# Altium schematic sheet MCU.SchDoc
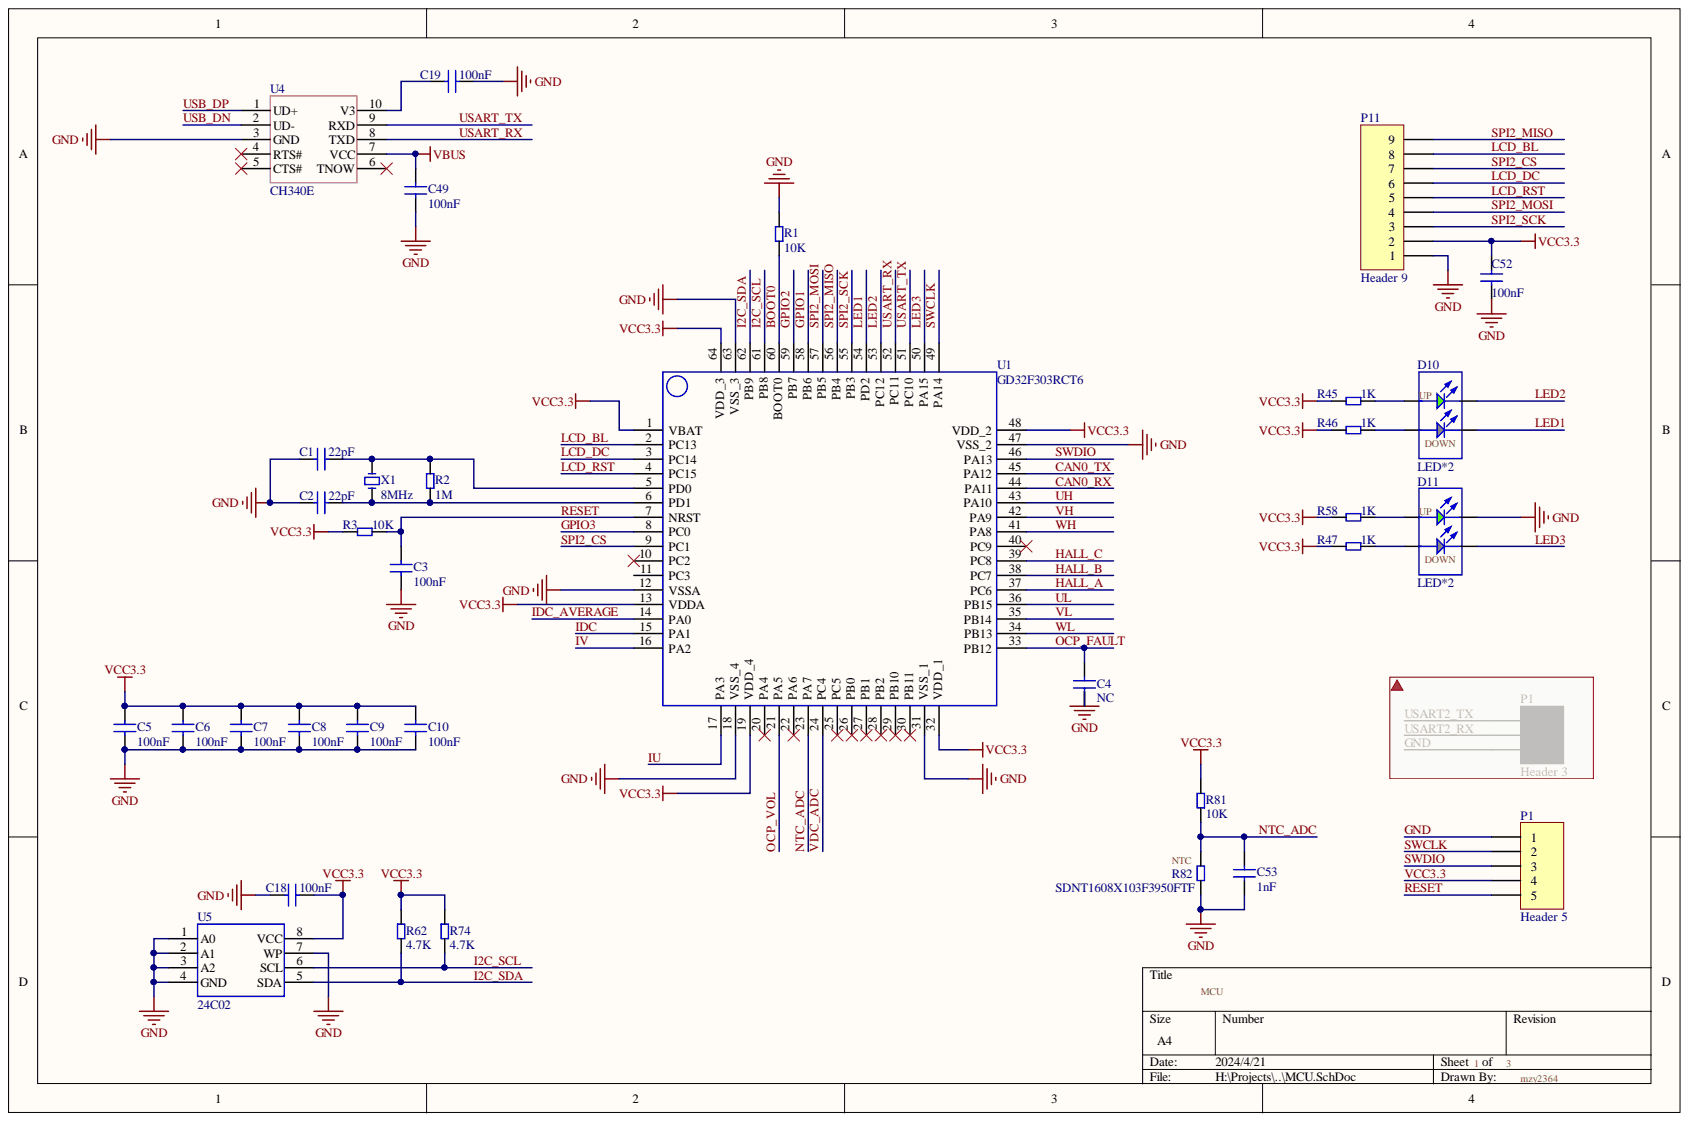
[diagram p0: MCU.SchDoc]

## Parts
C1  22pF  [C0603]
C2  22pF  [C0603]
C3  100nF  [C0603]
C4  NC  [C0603]
C5  100nF  [C0603]
C6  100nF  [C0603]
C7  100nF  [C0603]
C8  100nF  [C0603]
C9  100nF  [C0603]
C10  100nF  [C0603]
C18  100nF  [C0603]
C19  100nF  [C0603]
C49  100nF  [C0603]
C52  100nF  [C0603]
C53  1nF  [C0603]
D10  LED*2  [LED*2立式]
D11  LED*2  [LED*2立式]
P1  Header 5  [HDR1X5]
P11  Header 9  [HDR2.54-M-LI-9P]
R1  10K  [RES0603]
R2  1M  [RES0603]
R3  10K  [RES0603]
R45  1K  [RES0603]
R46  1K  [RES0603]
R47  1K  [RES0603]
R58  1K  [RES0603]
R62  4.7K  [RES0603]
R74  4.7K  [RES0603]
R81  10K  [RES0603]
R82  SDNT1608X103F3950FTF  [RES0603]
U1  GD32F303RCT6  [LQFP64-0.5]
U4  CH340E  [MSOP-10]
U5  24C02  [SOP8]
X1  8MHz  [OSC 5032-2P]

## Nets
VBUS: C49.2 U4.7(VCC)
BOOT0: R1.1 U1.60(BOOT0)
CAN0_RX: U1.44(PA11)
CAN0_TX: U1.45(PA12)
GND: C1.1 C2.1 C3.1 C4.1 C5.1 C6.1 C7.1 C8.1 C9.1 C10.1 C18.2 C19.1 C49.1 C52.1 C53.1 D11.2-2 P1.1 P11.1 R1.2 R82.1 U1.12(VSSA) U1.18(VSS_4) U1.31(VSS_1) U1.47(VSS_2) U1.63(VSS_3) U4.3(GND) U5.1(A0) U5.2(A1) U5.3(A2) U5.4(GND) U5.7(WP)
GPIO1: U1.58(PB6)
GPIO2: U1.59(PB7)
GPIO3: U1.8(PC0)
HALL_A: U1.37(PC6)
HALL_B: U1.38(PC7)
HALL_C: U1.39(PC8)
I2C_SCL: R74.2 U1.61(PB8) U5.6(SCL)
I2C_SDA: R62.2 U1.62(PB9) U5.5(SDA)
IDC: U1.15(PA1)
IDC_AVERAGE: U1.14(PA0)
IU: U1.17(PA3)
IV: U1.16(PA2)
LCD_BL: P11.8 U1.2(PC13)
LCD_DC: P11.6 U1.3(PC14)
LCD_RST: P11.5 U1.4(PC15)
LED1: D10.1-2 U1.54(PD2)
LED2: D10.2-2 U1.53(PC12)
LED3: D11.1-2 U1.50(PA15)
NTC_ADC: C53.2 R81.1 R82.2 U1.23(PA7)
OCP_FAULT: C4.2 U1.33(PB12)
OCP_VOL: U1.21(PA5)
RESET: C3.2 P1.5 R3.1 U1.7(NRST)
SPI2_CS: P11.7 U1.9(PC1)
SPI2_MISO: P11.9 U1.56(PB4)
SPI2_MOSI: P11.4 U1.57(PB5)
SPI2_SCK: P11.3 U1.55(PB3)
SWCLK: P1.2 U1.49(PA14)
SWDIO: P1.3 U1.46(PA13)
UH: U1.43(PA10)
UL: U1.36(PB15)
USART_RX: U1.52(PC11) U4.8(TXD)
USART_TX: U1.51(PC10) U4.9(RXD)
USB_DN: U4.2(UD-)
USB_DP: U4.1(UD+)
VCC3.3: C5.2 C6.2 C7.2 C8.2 C9.2 C10.2 C18.1 C52.2 P1.4 P11.2 R3.2 R45.2 R46.2 R47.2 R58.2 R62.1 R74.1 R81.2 U1.1(VBAT) U1.13(VDDA) U1.19(VDD_4) U1.32(VDD_1) U1.48(VDD_2) U1.64(VDD_3) U5.8(VCC)
VDC_ADC: U1.24(PC4)
VH: U1.42(PA9)
VL: U1.35(PB14)
WH: U1.41(PA8)
WL: U1.34(PB13)
NetC1_2: C1.2 R2.2 U1.5(PD0) X1.2
NetC2_2: C2.2 R2.1 U1.6(PD1) X1.1
NetC19_2: C19.2 U4.10(V3)
NetD10_1-1: D10.1-1 R46.1
NetD10_2-1: D10.2-1 R45.1
NetD11_1-1: D11.1-1 R47.1
NetD11_2-1: D11.2-1 R58.1

Not connected: U1 10(PC2) 11(PC3) 20(PA4) 22(PA6) 25(PC5) 26(PB0) 27(PB1) 28(PB2) 29(PB10) 30(PB11) 40(PC9); U4 4(RTS#) 5(CTS#) 6(TNOW)

## Library data of the parts
C1-C10 C18 C53 = CAP: Material: X7R; Precision: 10%; Voltage: 50V; Component Kind: Standard; Library Name: mzy2364.SchLib
C19 C49 C52 = CAP: Component Kind: Standard; Library Name: mzy2364.SchLib
P1 = Header 5: Header, 5-Pin; Component Kind: Standard; LatestRevisionDate: 17-Jul-2002; LatestRevisionNote: Re-released for DXP Platform.; Library Name: Miscellaneous Connectors.IntLib; Signal Integrity: Connector; Publisher: Altium Limited
P11 = Header 9: Header, 9-Pin; Component Kind: Standard; LatestRevisionDate: 17-Jul-2002; LatestRevisionNote: Re-released for DXP Platform.; Library Name: Miscellaneous Connectors.IntLib; Signal Integrity: Connector; Publisher: Altium Limited
R1-R3 R45-R47 R58 R62 R74 R81 R82 = RES: Component Kind: Standard; Library Name: mzy2364.SchLib
U1 = GD32F303RBT6: Component Kind: Standard; Library Name: mzy2364.SchLib
U4 = CH340E: USB转TTL; Component Kind: Standard; Library Name: mzy2364.SchLib
X1 = XTAL-2P: Component Kind: Standard; Library Name: mzy2364.SchLib
D10 D11 = LED*2: Component Kind: Standard; Library Name: mzy2364.SchLib
U5 = 24C02: Component Kind: Standard; Library Name: mzy2364.SchLib
